# Altium schematic sheet NucleoShield.SchDoc(NucleoShield)
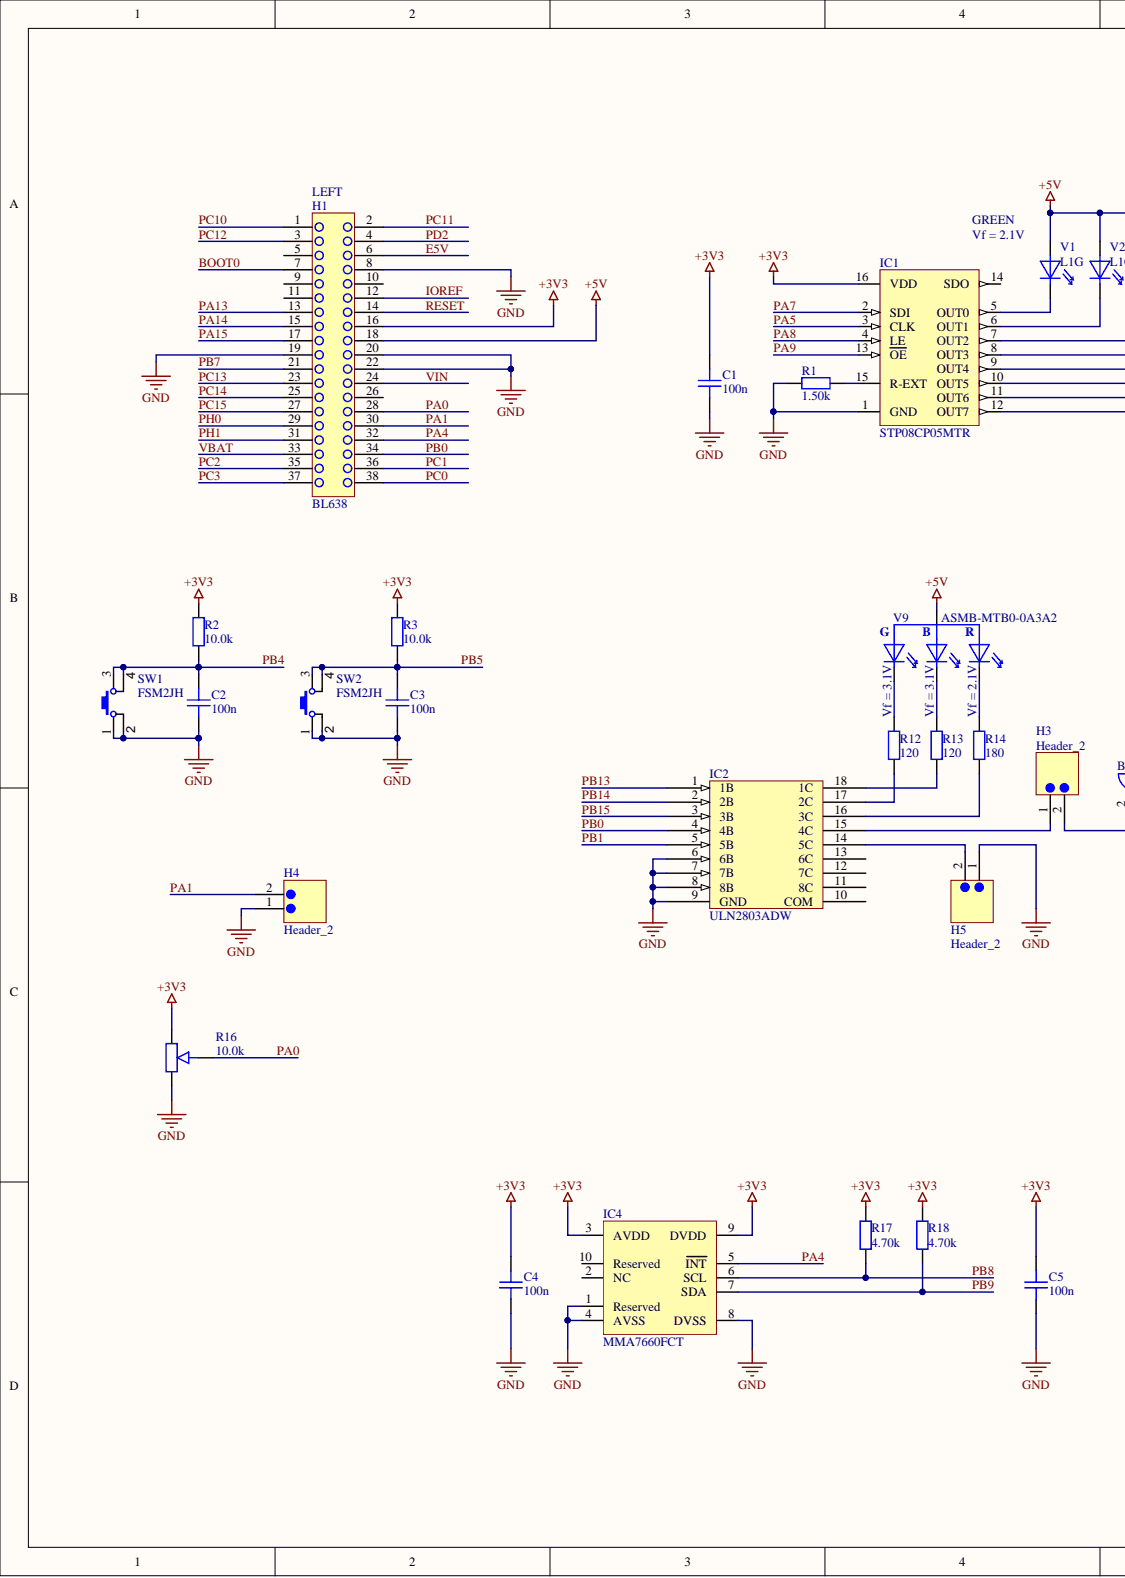
[diagram p0: NucleoShield.SchDoc, left]
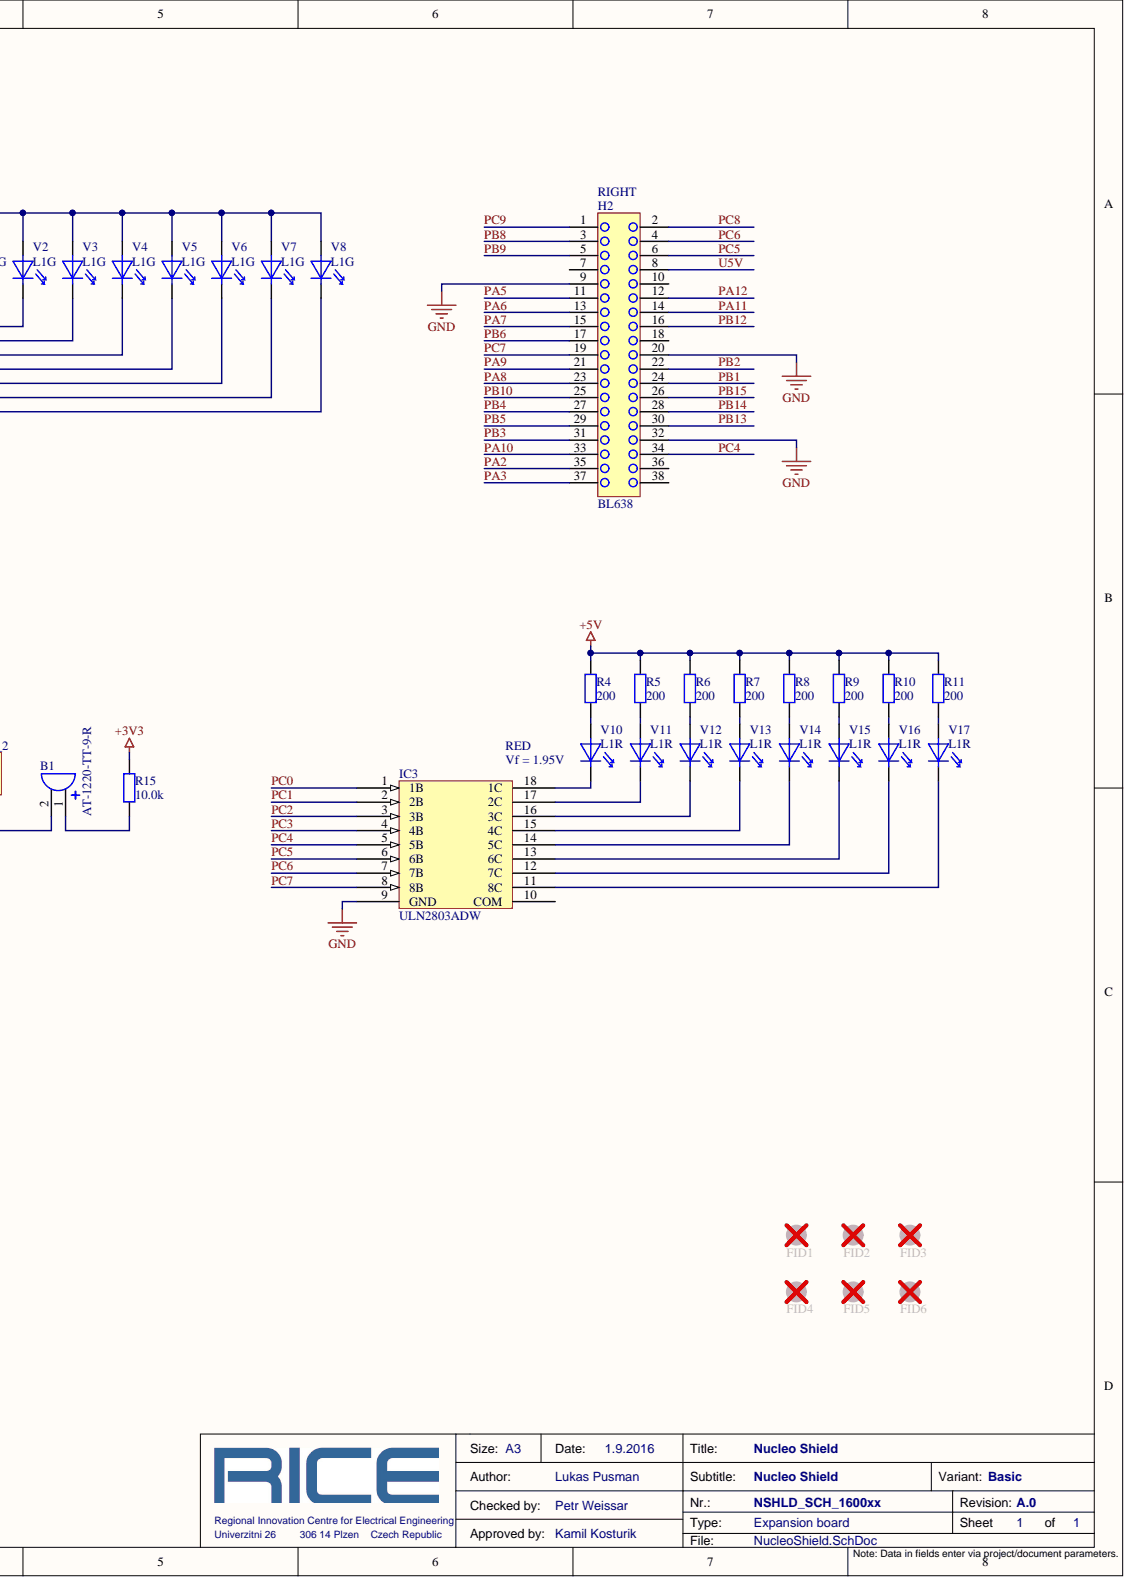
[diagram p1: NucleoShield.SchDoc, right]

## Parts
B1  AT-1220-TT-9-R  [th_buz_AT-1220-TT-9-R]
C1  100n  [sm_cap_0603]
C2  100n  [sm_cap_0603]
C3  100n  [sm_cap_0603]
C4  100n  [sm_cap_0603]
C5  100n  [sm_cap_0603]
FID1  Fiducial  [SM_mch_FIDUCIAL]  (value not drawn)
FID2  Fiducial  [SM_mch_FIDUCIAL]  (value not drawn)
FID3  Fiducial  [SM_mch_FIDUCIAL]  (value not drawn)
FID4  Fiducial  [SM_mch_FIDUCIAL]  (value not drawn)
FID5  Fiducial  [SM_mch_FIDUCIAL]  (value not drawn)
FID6  Fiducial  [SM_mch_FIDUCIAL]  (value not drawn)
H1  BL638  [th_con_rec2x19_pit100]
H2  BL638  [th_con_rec2x19_pit100]
H3  Header_2  [th_con_HDR1X2_pit100]
H4  Header_2  [th_con_HDR1X2_pit100]
H5  Header_2  [th_con_HDR1X2_pit100]
IC1  STP08CP05MTR  [SM_IO_SO16_W160]
IC2  ULN2803ADW  [SM_IO_SOIC18_PIT50]
IC3  ULN2803ADW  [SM_IO_SOIC18_PIT50]
IC4  MMA7660FCT  [SM_IO_VFDFN10]
R1  1.50k  [sm_res_0603]
R2  10.0k  [sm_res_0603]
R3  10.0k  [sm_res_0603]
R4  200  [sm_res_0603]
R5  200  [sm_res_0603]
R6  200  [sm_res_0603]
R7  200  [sm_res_0603]
R8  200  [sm_res_0603]
R9  200  [sm_res_0603]
R10  200  [sm_res_0603]
R11  200  [sm_res_0603]
R12  120  [sm_res_0603]
R13  120  [sm_res_0603]
R14  180  [sm_res_0603]
R15  10.0k  [sm_res_0603]
R16  10.0k  [TH_RES_TRIM_PT15]
R17  4.70k  [sm_res_0603]
R18  4.70k  [sm_res_0603]
SW1  FSM2JH  [th_swi_lph]
SW2  FSM2JH  [th_swi_lph]
V1  L1G  [sm_opt_led0805]
V2  L1G  [sm_opt_led0805]
V3  L1G  [sm_opt_led0805]
V4  L1G  [sm_opt_led0805]
V5  L1G  [sm_opt_led0805]
V6  L1G  [sm_opt_led0805]
V7  L1G  [sm_opt_led0805]
V8  L1G  [sm_opt_led0805]
V9  ASMB-MTB0-0A3A2  [sm_opt_LED_PLCC-4]
V10  L1R  [sm_opt_led0805]
V11  L1R  [sm_opt_led0805]
V12  L1R  [sm_opt_led0805]
V13  L1R  [sm_opt_led0805]
V14  L1R  [sm_opt_led0805]
V15  L1R  [sm_opt_led0805]
V16  L1R  [sm_opt_led0805]
V17  L1R  [sm_opt_led0805]

## Nets
+3V3: C1.2 C4.2 C5.2 H1.16 IC1.16(VDD) IC4.3(AVDD) IC4.9(DVDD) R2.2 R3.2 R15.2 R16.3 R17.2 R18.2
+5V: H1.18 R4.2 R5.2 R6.2 R7.2 R8.2 R9.2 R10.2 R11.2 V1.1 V2.1 V3.1 V4.1 V5.1 V6.1 V7.1 V8.1 V9.3
GND: C1.1 C2.1 C3.1 C4.1 C5.1 H1.8 H1.19 H1.20 H1.22 H2.9 H2.20 H2.32 H4.1 H5.1 IC1.1(GND) IC2.6(6B) IC2.7(7B) IC2.8(8B) IC2.9(GND) IC3.9(GND) IC4.1(Reserved) IC4.4(AVSS) IC4.8(DVSS) R1.1 R16.1 SW1.1 SW1.2 SW2.1 SW2.2
BOOT0: H1.7
E5V: H1.6
IOREF: H1.12
PA0: H1.28 R16.2
PA1: H1.30 H4.2
PA2: H2.35
PA3: H2.37
PA4: H1.32 IC4.5(INT)
PA5: H2.11 IC1.3(CLK)
PA6: H2.13
PA7: H2.15 IC1.2(SDI)
PA8: H2.23 IC1.4(LE)
PA9: H2.21 IC1.13(OE)
PA10: H2.33
PA11: H2.14
PA12: H2.12
PA13: H1.13
PA14: H1.15
PA15: H1.17
PB0: H1.34 IC2.4(4B)
PB1: H2.24 IC2.5(5B)
PB2: H2.22
PB3: H2.31
PB4: C2.2 H2.27 R2.1 SW1.3 SW1.4
PB5: C3.2 H2.29 R3.1 SW2.3 SW2.4
PB6: H2.17
PB7: H1.21
PB8: H2.3 IC4.6(SCL) R17.1
PB9: H2.5 IC4.7(SDA) R18.1
PB10: H2.25
PB12: H2.16
PB13: H2.30 IC2.1(1B)
PB14: H2.28 IC2.2(2B)
PB15: H2.26 IC2.3(3B)
PC0: H1.38 IC3.1(1B)
PC1: H1.36 IC3.2(2B)
PC2: H1.35 IC3.3(3B)
PC3: H1.37 IC3.4(4B)
PC4: H2.34 IC3.5(5B)
PC5: H2.6 IC3.6(6B)
PC6: H2.4 IC3.7(7B)
PC7: H2.19 IC3.8(8B)
PC8: H2.2
PC9: H2.1
PC10: H1.1
PC11: H1.2
PC12: H1.3
PC13: H1.23
PC14: H1.25
PC15: H1.27
PD2: H1.4
PH0: H1.29
PH1: H1.31
RESET: H1.14
U5V: H2.8
VBAT: H1.33
VIN: H1.24
NetB1_1: B1.1 R15.1
NetB1_2: B1.2 H3.2
NetH3_1: H3.1 IC2.15(4C)
NetH5_2: H5.2 IC2.14(5C)
NetIC1_5: IC1.5(OUT0) V1.2
NetIC1_6: IC1.6(OUT1) V2.2
NetIC1_7: IC1.7(OUT2) V3.2
NetIC1_8: IC1.8(OUT3) V4.2
NetIC1_9: IC1.9(OUT4) V5.2
NetIC1_10: IC1.10(OUT5) V6.2
NetIC1_11: IC1.11(OUT6) V7.2
NetIC1_12: IC1.12(OUT7) V8.2
NetIC1_15: IC1.15(R-EXT) R1.2
NetIC2_16: IC2.16(3C) R14.1
NetIC2_17: IC2.17(2C) R12.1
NetIC2_18: IC2.18(1C) R13.1
NetIC3_11: IC3.11(8C) V17.2
NetIC3_12: IC3.12(7C) V16.2
NetIC3_13: IC3.13(6C) V15.2
NetIC3_14: IC3.14(5C) V14.2
NetIC3_15: IC3.15(4C) V13.2
NetIC3_16: IC3.16(3C) V12.2
NetIC3_17: IC3.17(2C) V11.2
NetIC3_18: IC3.18(1C) V10.2
NetR4_1: R4.1 V10.1
NetR5_1: R5.1 V11.1
NetR6_1: R6.1 V12.1
NetR7_1: R7.1 V13.1
NetR8_1: R8.1 V14.1
NetR9_1: R9.1 V15.1
NetR10_1: R10.1 V16.1
NetR11_1: R11.1 V17.1
NetR12_2: R12.2 V9.1
NetR13_2: R13.2 V9.2
NetR14_2: R14.2 V9.4

Not connected: H1 5 9 10 11 26; H2 7 10 18 36 38; IC1 14(SDO); IC2 10(COM) 11(8C) 12(7C) 13(6C); IC3 10(COM); IC4 2(NC) 10(Reserved)

## Library data of the parts
B1 = MOD_000020: Magnetic Signal Buzzer, Single Tone 2.048kHz, 3.0-5.0Vp-p, 35mA, 85dB@3.5V/10cm; Manufacturer: PUI Audio, Inc.; ManufacturerID: PUIAudio; Part Category: Comunication/acustic; Part Select: TMOD0001000800000000; Supp Part number: 668-1468-ND; Active: 1; Cat1: Comunication; Cat2: acustic; Database Table Name: _modules; Designer: Lukas Pusman; Exact price 2: 0; ID: 4186; LasrRevisionNote: new release; Max Oper Temperature: 70; Min Oper Temperature: -20; PCB Status: electrical; Alternative: 1; Component Kind: Standard; ComponentLink1Description: Datasheet; ComponentLink1URL: \\afs\zcu.cz\project\departments\fel\rice\projects\General\Libraries\LIB_Components\PDF\Semiconductor\Modules\AT-1220-TT-9-R.pdf; ComponentLink2Description: Magnetic Buzzer; Exact price: 1; Exaxt price 3: 0; FIT: 1; LastRevisionDate: 11.5.2016; Library Name: Lib_Components_SQL.DbLib; ManufacturerURL: http://www.puiaudio.com/; Manuf Part Number: AT-1220-TT-9-R; Part Number: MOD_000020; PartNumber: MOD_000020; PartQuantity: 1; Part type: MOD; Preference: 1; Price: 0; Price 2: 0; Price 3: 0; Publishied: 11.5.2016; ROHS: 1; Simulation: nosim; Stock: 0; Supplier: Digikey; Supplier2: N/A; Supplier3: N/A; SupplierID: Digikey; SupplierID2: no supplier; SupplierID3: no supplier; SupplierURL: http://www.digikey.com/; SupplierURL2: N/A; SupplierURL3: N/A; Value: AT-1220-TT-9-R; Publisher: Jindřich Křivka
C1-C5 = CAP_000000: CAP CER .10UF 50V X7R 10% 0603; Manufacturer: TDK Corporation; ManufacturerID: TDK; Manuf Part Number: C1608X7R1H104K; Part Category: Ceramic/0603/X7R; Part Select: SCAP0001000300026100; Supp Part number: 445-1314-2-ND; Active: 1; Cat1: Ceramic; Cat2: 0603; Database Table Name: _capacitors; Designer: Tomáš Košan; FIT: 0,100000001490116; ID: 4; LasrRevisionNote: new release; Max Oper Temperature: 125; Min Oper Temperature: -55; PCB Status: Electrical; Preference: 100; Alternative: 1; Cat3: X7R; Component Kind: Standard; ComponentLink1Description: Datasheet; ComponentLink1URL: \\afs\zcu.cz\project\departments\fel\rice\projects\General\Libraries\LIB_Components\PDF\passive\capacitors\Cxxxx_TDK.pdf; Dielectric: X7R; Exact price: 1; LastRevisionDate: 11.5.2011; Library Name: Lib_Components_SQL.DbLib; ManufacturerURL: http://www.tdk.com/; Part Number: CAP_000000; PartNumber: CAP_000000; PartQuantity: 1; Part type: CAP; PCB3D: sm_cap_0603; Price: 0,112099997699261; Price 2: 0; Price 3: 0; Publishied: 11.5.2011; Quantity: 1; ROHS: 1; Simulation: cap; Stock: 4077; Supplier: Digikey; Supplier2: N/A; Supplier3: N/A; SupplierID: Digikey; SupplierID2: no supplier; SupplierID3: no supplier; SupplierURL: http://www.digikey.com/; SupplierURL2: N/A; SupplierURL3: N/A; Tolerance: 10%; Value: 100n; Voltage: 50V; Publisher: Libor Polacek
FID1-FID6 = MCH_000004: Fiducial global circle 1mm; ManufacturerID: no manufacturer; Manuf Part Number: x; Part Category: Virtual/Fiducial; Part Select: SMCH0002000200000000; Active: 1; Cat1: Virtual; Database Table Name: _Mechanicals; Designer: Libor Polacek; Exact price: 0; ID: 1091; LastRevisionNote: new release; Alternative: 1; Cat2: Fiducial; Component Kind: Standard; ComponentLink1Description: Datasheet; ComponentLink1URL: \\afs\zcu.cz\project\departments\fel\rice\projects\General\Libraries\LIB_Components\PDF\; ComponentLink2URL: \\afs\zcu.cz\project\departments\fel\rice\projects\General\Libraries\LIB_Components\PDF\; FIT: 0; Function Type: fiducial; LastRevisionDate: 8.9.2011; Library Name: Lib_Components_SQL.DbLib; Max Oper Temperature: 0; Min Oper Temperature: 0; Part Number: MCH_000004; PartNumber: MCH_000004; PartQuantity: 1; Part type: MCH; PCB3D: sm_vir_fiducial; PCB Status: Virtual; Preference: 0; Price: 0; Price 2: 0; Price 3: 0; Publishied: 8.9.2011; Quantity: 1; ROHS: 1; Simulation: nosim; Stock: 0; Supplier: N/A; Supplier2: N/A; Supplier3: N/A; SupplierID: no supplier; SupplierID2: no supplier; SupplierID3: no supplier; SupplierURL: N/A; SupplierURL2: N/A; SupplierURL3: N/A; Supp Part number: x; Value: Fiducial; Publisher: Libor Polacek
H1 H2 = CON_000292: CON Receptacle 2x19 100 mil; Manufacturer: Fischer Elektronik; ManufacturerID: Fischer; Part Category: Pin rows/Receptacle; Part Select: TCON0001000200002400; Active: 1; Cat1: Pin rows; Database Table Name: _connectors; Design: RECEPTACLE 100mil; Designer: Lukas Pusman; Exact price: 0; FIT: 10; ID: 4180; Max Oper Temperature: 125; Min Oper Temperature: -65; PCB Status: Electrical; Alternative: 1; Cat2: Receptacle; Component Kind: Standard; ComponentLink1Description: Datasheet; ComponentLink1URL: \\afs\zcu.cz\project\departments\fel\rice\projects\General\Libraries\LIB_Components\PDF\Passive\Connectors\f.con.e.pdf#page=63; Exact price 2: 0; Exaxt price 3: 0; LatestRevisionDate: 09-Sep-2011; LatestRevisionNote: Re-released for DXP Platform.; Library Name: Lib_Components_SQL.DbLib; ManufacturerURL: http://www.fischerelektronik.de/index.php/home_en/?&L=1; Manuf Part Number: BL638; Part Number: CON_000292; PartNumber: CON_000292; PartQuantity: 1; Part type: CON; Pin: 38; Preference: 0; Price: 10; Price 2: 0; Price 3: 0; Published: 15.6.2011; ROHS: 1; Signal Integrity: Connector; Simulation: nosim; Stock: 0; Supplier: Fischer Elektronik; Supplier2: N/A; Supplier3: N/A; SupplierID: Fischer; SupplierID2: no supplier; SupplierID3: no supplier; SupplierURL: http://www.fischerelektronik.de/index.php/home_en/?&L=1; SupplierURL2: N/A; SupplierURL3: N/A; Supp Part number: BL638; Value: BL638; Publisher: Altium Limited
H3-H5 = CON_000006: CON 2pin header 100mill 5,4mm; Manufacturer: Fischer Elektronik; ManufacturerID: Fischer; Manuf Part Number: SL1111202G; Part Category: Pin rows/Header; Part Select: TCON0001000100001200; Active: 1; Cat1: Pin rows; Database Table Name: _connectors; Designer: Libor Polacek; Eratasheet: \\afs\zcu.cz\project\departments\fel\rice\projects\General\Libraries\LIB_Components\PDF\; Exact price: 0; FIT: 2; ID: 901; LastRevisionNote: Initial version; Max Oper Temperature: 125; Min Oper Temperature: -65; PCB Status: Electrical; Alternative: 1; Cat2: Header; Component Kind: Standard; ComponentLink1Description: Datasheet; ComponentLink1URL: \\afs\zcu.cz\project\departments\fel\rice\projects\General\Libraries\LIB_Components\PDF\Passive\Connectors\f.con.e.pdf#page=40; Design: HEADER 100mill; LastRevisionDate: 15.6.2011; Library Name: Lib_Components_SQL.DbLib; ManufacturerURL: http://www.fischerelektronik.de/index.php/home_en/?&L=1; Part Number: CON_000006; PartNumber: CON_000006; PartQuantity: 1; Part type: CON; PCB3D: th_con_HDR1X2; Pin: 2; Preference: 0; Price: 0; Price 2: 0; Price 3: 0; Published: 15.6.2011; ROHS: 1; Signal Integrity: Connector; Simulation: nosim; Stock: 30; Supplier: Fischer Elektronik; Supplier2: N/A; Supplier3: N/A; SupplierID: Fischer; SupplierID2: no supplier; SupplierID3: no supplier; SupplierURL: http://www.fischerelektronik.de/index.php/home_en/?&L=1; SupplierURL2: N/A; SupplierURL3: N/A; Supp Part number: SL1111202G; Value: Header_2; Publisher: Turjanica
IC1 = ICS_000539: ICS 8-Bit Parallel-Out Serial Shift Register 3.0-5.5V 20ns SOIC16; Manufacturer: ST Microelectronics; ManufacturerID: STM; Part Category: Digital/Shift register; Part Select: SICS0002000800000000; Supp Part number: 497-6268-1-ND; Active: 1; Cat1: Digital; Database Table Name: _ics; Designer: Lukas Pusman; Exact price 2: 0; FIT: 8; ID: 4184; LastRevisionNote: new release; Max Oper Temperature: 125; Min Oper Temperature: -40; PCB Status: Electrical; Alternative: 1; Cat2: Shift register; Component Kind: Standard; ComponentLink1Description: Datasheet; ComponentLink1URL: \\afs\zcu.cz\project\departments\fel\rice\projects\General\Libraries\LIB_Components\PDF\Semiconductor\Logic\ShiftRegister\STP08CP05.pdf; ComponentLink2Description: Family; Exact price: 1; Exaxt price 3: 0; Function Type: Shift register; LastRevisionDate: 20.7.2016; Library Name: Lib_Components_SQL.DbLib; ManufacturerURL: http://www.st.com/internet/com/home/home.jsp; Manuf Part Number: STP08CP05MTR; Part Number: ICS_000539; PartNumber: ICS_000539; PartQuantity: 1; Part type: ICS; Preference: 0; Price: 21; Price 2: 0; Price 3: 0; Published: 20.7.2016; ROHS: 1; Simulation: nosim; Stock: 0; Supplier: Digikey; Supplier2: N/A; Supplier3: N/A; SupplierID: Digikey; SupplierID2: no supplier; SupplierID3: no supplier; SupplierURL: http://www.digikey.com/; SupplierURL2: N/A; SupplierURL3: N/A; Technology: CMOS; Value: STP08CP05MTR; Publisher: Lukas Pusman
IC2 IC3 = ICS_000102: ICS 8x NPN darlington, 50V, 0.5A, common clamping diode; Manufacturer: Texas Instruments; ManufacturerID: TI; Part Category: Digital/Level shifter; Part Select: SICS0002000600000000; Supp Part number: GES05014097; Supp Part number 2: 296-15777-1-ND; Active: 1; Cat1: Digital; Cat2: Level shifter; Database Table Name: _ics; Designer: Tomáš Košan; Exact price 2: 0; Function Type: Power level shifter; ID: 1394; LastRevisonNote: new release; Max Oper Temperature: 85; Min Oper Temperature: -40; PCB Status: Electrical; Alternative: 1; Component Kind: Standard; ComponentLink1Description: Datasheet; ComponentLink1URL: \\afs\zcu.cz\project\departments\fel\rice\projects\General\Libraries\LIB_Components\PDF\Semiconductor\Logic\LevelShifter\uln2803ad.pdf; Exact price: 1; Exaxt price 3: 0; FIT: 0; LastRevisionDate: 21.12.2011; Library Name: Lib_Components_SQL.DbLib; ManufacturerURL: http://www.ti.com/; Manuf Part Number: ULN2803ADW; Marking: ULN2803A; Part Number: ICS_000102; PartNumber: ICS_000102; PartQuantity: 1; Part type: ICS; Preference: 1; Price: 18,8999996185303; Price 2: 18; Price 3: 0; Published: 21.12.2011; ROHS: 1; Simulation: nosim; Stock: 0; Supplier: GES; Supplier2: Digikey; Supplier3: N/A; SupplierID: GES; SupplierID2: Digikey; SupplierID3: no supplier; SupplierURL: http://www.ges.cz/cz/; SupplierURL2: http://www.digikey.com/; SupplierURL3: N/A; Technology: TTL; Value: ULN2803ADW; Publisher: Tomas Kosan
IC4 = ICS_000538: 3-Axis Digital Accelerometer, 6-Bit, I2C, +-1.5g; Manufacturer: Freescale; Part Category: Digital/sensor/Acceleration; Part Select: SICS0002001600030000; Supp Part number: MMA7660FCT-ND; Active: 1; Cat2: sensor; Cat3: Acceleration; Database Table Name: _ics; Designer: Lukas Pusman; Exaxt price 3: 0; Function Type: 3-Axis Accelerometer; ID: 4182; LastRevisionNote: new release; Max Oper Temperature: 85; Min Oper Temperature: -40; PCB Status: Electrical; Alternative: 1; Cat1: Digital; Component Kind: Standard; ComponentLink1Description: Datasheet; ComponentLink1URL: \\afs\zcu.cz\project\departments\fel\rice\projects\General\Libraries\LIB_Components\PDF\Semiconductor\Logic\Converter\Acceleration\MMA7660FCT.pdf; Exact price: 1; Exact price 2: 1; FIT: 1; LastRevisionDate: 26.5.2014; Library Name: Lib_Components_SQL.DbLib; ManufacturerID: Freescale; ManufacturerURL: http://www.freescale.com/; Manuf Part Number: MMA7660FCT; Part Number: ICS_000538; PartNumber: ICS_000538; PartQuantity: 1; Part type: ICS; Preference: 0; Price: 38; Price 2: 0; Price 3: 0; Published: 26.5.2014; ROHS: 1; Simulation: nosim; Stock: 0; Supplier: Digikey; Supplier2: N/A; Supplier3: N/A; SupplierID: Digikey; SupplierID2: no supplier; SupplierID3: no supplier; SupplierURL: http://www.digikey.com/; SupplierURL2: N/A; SupplierURL3: N/A; Technology: MEMS; Value: MMA7660FCT; Publisher: Michael Holik
R1 = RES_000077: RES 0603 1.50k 1% 100ppm SMD thick film; Manufacturer: Panasonic; Manuf Part Number: ERJ3EKF1501V; Part Category: Thick film/0603; Part Select: SRES0001000300004150; Supp Part number: P1.50kHTR-ND; Active: 1; Cat2: 0603; Database Table Name: _Resistors; Designer: LiPo; FIT: 0,100000001490116; ID: 438; LastRevisionNote: new release; Max Oper Temperature: 125; Min Oper Temperature: -55; PCB Status: Electrical; Power: 63mW; Alternative: 1; Cat1: Thick film; Component Kind: Standard; ComponentLink1Description: Datasheet; ComponentLink1URL: \\afs\zcu.cz\project\departments\fel\rice\projects\General\Libraries\LIB_Components\PDF\passive\resistors\ERJ_EKF_Panasonic.pdf; Exact price: 1; LastRevisionDate: 11.5.2011; Library Name: Lib_Components_SQL.DbLib; ManufacturerID: Panasonic; ManufacturerURL: http://www.industrial.panasonic.com/; Part Number: RES_000077; PartNumber: RES_000077; PartQuantity: 1; Part type: RES; PCB3D: sm_res_0603; Price: 0,0044999998062849; Price 2: 0; Price 3: 0; Published: 11.5.2011; Quantity: 1; ROHS: 1; Simulation: res; Stock: 3623; Supplier: Digikey; Supplier2: N/A; Supplier3: N/A; SupplierID: Digikey; SupplierID2: no supplier; SupplierID3: no supplier; SupplierURL: http://www.digikey.com/; SupplierURL2: N/A; SupplierURL3: N/A; Thermal Stability: 100ppm; Tolerance: 1%; Value: 1.50k; Publisher: Libor Polacek
R2 R3 R15 = RES_000097: RES 0603 10.0k 1% 100ppm SMD thick film; Manufacturer: Panasonic; Manuf Part Number: ERJ3EKF1002V; Part Category: Thick film/0603; Part Select: SRES0001000300005100; Supp Part number: P10.0kHTR-ND; Active: 1; Cat2: 0603; Database Table Name: _resistors; Designer: LiPo; FIT: 0,100000001490116; ID: 458; LastRevisionNote: new release; Max Oper Temperature: 125; Min Oper Temperature: -55; PCB Status: Electrical; Power: 63mW; Alternative: 1; Cat1: Thick film; Component Kind: Standard; ComponentLink1Description: Datasheet; ComponentLink1URL: \\afs\zcu.cz\project\departments\fel\rice\projects\General\Libraries\LIB_Components\PDF\passive\resistors\ERJ_EKF_Panasonic.pdf; Exact price: 1; LastRevisionDate: 11.5.2011; Library Name: Lib_Components_SQL.DbLib; ManufacturerID: Panasonic; ManufacturerURL: http://www.industrial.panasonic.com/; Part Number: RES_000097; PartNumber: RES_000097; PartQuantity: 1; Part type: RES; PCB3D: sm_res_0603; Price: 0,0044999998062849; Price 2: 0; Price 3: 0; Published: 11.5.2011; Quantity: 1; ROHS: 1; Simulation: res; Stock: 1663; Supplier: Digikey; Supplier2: N/A; Supplier3: N/A; SupplierID: Digikey; SupplierID2: no supplier; SupplierID3: no supplier; SupplierURL: http://www.digikey.com/; SupplierURL2: N/A; SupplierURL3: N/A; Thermal Stability: 100ppm; Tolerance: 1%; Value: 10.0k; Publisher: Libor Polacek
R4-R11 = RES_000056: RES 0603 200R 1% 100ppm SMD thick film; Manufacturer: Panasonic; Manuf Part Number: ERJ3EKF2000V; Part Category: Thick film/0603; Part Select: SRES0001000300003200; Supp Part number: P200HTR-ND; Active: 1; Cat2: 0603; Database Table Name: _Resistors; Designer: LiPo; FIT: 0,100000001490116; ID: 417; LastRevisionNote: new release; Max Oper Temperature: 125; Min Oper Temperature: -55; PCB Status: Electrical; Power: 63mW; Alternative: 1; Cat1: Thick film; Component Kind: Standard; ComponentLink1Description: Datasheet; ComponentLink1URL: \\afs\zcu.cz\project\departments\fel\rice\projects\General\Libraries\LIB_Components\PDF\passive\resistors\ERJ_EKF_Panasonic.pdf; Exact price: 1; LastRevisionDate: 11.5.2011; Library Name: Lib_Components_SQL.DbLib; ManufacturerID: Panasonic; ManufacturerURL: http://www.industrial.panasonic.com/; Part Number: RES_000056; PartNumber: RES_000056; PartQuantity: 1; Part type: RES; PCB3D: sm_res_0603; Price: 0,0044999998062849; Price 2: 0; Price 3: 0; Published: 11.5.2011; Quantity: 1; ROHS: 1; Simulation: res; Stock: 4885; Supplier: Digikey; Supplier2: N/A; Supplier3: N/A; SupplierID: Digikey; SupplierID2: no supplier; SupplierID3: no supplier; SupplierURL: http://www.digikey.com/; SupplierURL2: N/A; SupplierURL3: N/A; Thermal Stability: 100ppm; Tolerance: 1%; Value: 200; Publisher: Libor Polacek
R12 R13 = RES_000051: RES 0603 120R 1% 100ppm SMD thick film; Manufacturer: Panasonic; Manuf Part Number: ERJ3EKF1200V; Part Category: Thick film/0603; Part Select: SRES0001000300003120; Supp Part number: P120HTR-ND; Active: 1; Cat2: 0603; Database Table Name: _resistors; Designer: LiPo; FIT: 0,100000001490116; ID: 412; LastRevisionNote: new release; Max Oper Temperature: 125; Min Oper Temperature: -55; PCB Status: Electrical; Power: 63mW; Alternative: 1; Cat1: Thick film; Component Kind: Standard; ComponentLink1Description: Datasheet; ComponentLink1URL: \\afs\zcu.cz\project\departments\fel\rice\projects\General\Libraries\LIB_Components\PDF\passive\resistors\ERJ_EKF_Panasonic.pdf; Exact price: 1; LastRevisionDate: 11.5.2011; Library Name: Lib_Components_SQL.DbLib; ManufacturerID: Panasonic; ManufacturerURL: http://www.industrial.panasonic.com/; Part Number: RES_000051; PartNumber: RES_000051; PartQuantity: 1; Part type: RES; PCB3D: sm_res_0603; Price: 0,0044999998062849; Price 2: 0; Price 3: 0; Published: 11.5.2011; Quantity: 1; ROHS: 1; Simulation: res; Stock: 4540; Supplier: Digikey; Supplier2: N/A; Supplier3: N/A; SupplierID: Digikey; SupplierID2: no supplier; SupplierID3: no supplier; SupplierURL: http://www.digikey.com/; SupplierURL2: N/A; SupplierURL3: N/A; Thermal Stability: 100ppm; Tolerance: 1%; Value: 120; Publisher: Libor Polacek
R14 = RES_000055: RES 0603 180R 1% 100ppm SMD thick film; Manufacturer: Panasonic; Manuf Part Number: ERJ3EKF1800V; Part Category: Thick film/0603; Part Select: SRES0001000300003180; Supp Part number: P180HTR-ND; Active: 1; Cat2: 0603; Database Table Name: _resistors; Designer: LiPo; FIT: 0,100000001490116; ID: 416; LastRevisionNote: new release; Max Oper Temperature: 125; Min Oper Temperature: -55; PCB Status: Electrical; Power: 63mW; Alternative: 1; Cat1: Thick film; Component Kind: Standard; ComponentLink1Description: Datasheet; ComponentLink1URL: \\afs\zcu.cz\project\departments\fel\rice\projects\General\Libraries\LIB_Components\PDF\passive\resistors\ERJ_EKF_Panasonic.pdf; Exact price: 1; LastRevisionDate: 11.5.2011; Library Name: Lib_Components_SQL.DbLib; ManufacturerID: Panasonic; ManufacturerURL: http://www.industrial.panasonic.com/; Part Number: RES_000055; PartNumber: RES_000055; PartQuantity: 1; Part type: RES; PCB3D: sm_res_0603; Price: 0,0044999998062849; Price 2: 0; Price 3: 0; Published: 11.5.2011; Quantity: 1; ROHS: 1; Simulation: res; Stock: 3496; Supplier: Digikey; Supplier2: N/A; Supplier3: N/A; SupplierID: Digikey; SupplierID2: no supplier; SupplierID3: no supplier; SupplierURL: http://www.digikey.com/; SupplierURL2: N/A; SupplierURL3: N/A; Thermal Stability: 100ppm; Tolerance: 1%; Value: 180; Publisher: Libor Polacek
R16 = RES_000622: RES VAR 10k, 20%; Manufacturer: Piher Sensors & Controls SA; ManufacturerID: Piher; Manuf Part Number: PT15NV02103A2020; Part Category: Variable/Trimmer; Part Select: TRES0008000100005100; Supp Part number: PT15NV-10K; Active: 1; Cat1: Variable; Cat2: Trimmer; Database Table Name: _resistors; Designer: Lukas Pusman; Exaxt price 3: 0; ID: 4218; LastRevisionNote: new release; Max Oper Temperature: 70; Min Oper Temperature: -25; PCB Status: Electrical; Power: 250mW; Alternative: 1; Component Kind: Standard; ComponentLink1Description: Datasheet; ComponentLink1URL: \\afs\zcu.cz\project\departments\fel\rice\projects\General\Libraries\LIB_Components\PDF\Passive\Resistors\Trimmer_Piher_PT15Nx.pdf; Exact price: 1; Exact price 2: 1; FIT: 0; LastRevisionDate: 11.5.2011; Library Name: Lib_Components_SQL.DbLib; ManufacturerURL: http://www.piher.net/sensors/; Part Number: RES_000622; PartNumber: RES_000622; PartQuantity: 1; Part type: RES; Preference: 0; Price: 11; Price 2: 0; Price 3: 0; Published: 11.5.2011; ROHS: 1; Simulation: nosim; Stock: 0; Supplier: TME; Supplier2: N/A; Supplier3: N/A; SupplierID: TME; SupplierID2: no supplier; SupplierID3: no supplier; SupplierURL: http://www.tme.eu/cz/; SupplierURL2: N/A; SupplierURL3: N/A; Tolerance: 20%; Value: 10.0k; Publisher: Libor Polacek
R17 R18 = RES_000089: RES 0603 4.70k 1% 100ppm SMD thick film; Manufacturer: Panasonic; Manuf Part Number: ERJ3EKF4701V; Part Category: Thick film/0603; Part Select: SRES0001000300004470; Supp Part number: P4.70kHTR-ND; Active: 1; Cat2: 0603; Database Table Name: _resistors; Designer: LiPo; FIT: 0,100000001490116; ID: 450; LastRevisionNote: new release; Max Oper Temperature: 125; Min Oper Temperature: -55; PCB Status: Electrical; Power: 63mW; Alternative: 1; Cat1: Thick film; Component Kind: Standard; ComponentLink1Description: Datasheet; ComponentLink1URL: \\afs\zcu.cz\project\departments\fel\rice\projects\General\Libraries\LIB_Components\PDF\passive\resistors\ERJ_EKF_Panasonic.pdf; Exact price: 1; LastRevisionDate: 11.5.2011; Library Name: Lib_Components_SQL.DbLib; ManufacturerID: Panasonic; ManufacturerURL: http://www.industrial.panasonic.com/; Part Number: RES_000089; PartNumber: RES_000089; PartQuantity: 1; Part type: RES; PCB3D: sm_res_0603; Price: 0,0044999998062849; Price 2: 0; Price 3: 0; Published: 11.5.2011; Quantity: 1; ROHS: 1; Simulation: res; Stock: 992; Supplier: Digikey; Supplier2: N/A; Supplier3: N/A; SupplierID: Digikey; SupplierID2: no supplier; SupplierID3: no supplier; SupplierURL: http://www.digikey.com/; SupplierURL2: N/A; SupplierURL3: N/A; Thermal Stability: 100ppm; Tolerance: 1%; Value: 4.70k; Publisher: Libor Polacek
SW1 SW2 = SWI_000006: MCH button switch; Manufacturer: TE connectivity; Part Category: Button/Closing; Part Select: TSWI0002000100000000; Supp Part number: 1555981; Active: 1; Cat2: Closing; Database Table Name: _switches; Designer: Jiri Cengery; Exact price 2: 0; FIT: 5; ID: 2202; Max Oper Temperature: 85; Min Oper Temperature: -40; PCB Status: Electrical; Alternative: 1; Cat1: Button; Component Kind: Standard; ComponentLink1Description: Datasheet; ComponentLink1URL: \\afs\zcu.cz\project\departments\fel\rice\projects\General\Libraries\LIB_Components\PDF\Passive\Switches\typ_LPV.pdf; ComponentLink2URL: \\afs\zcu.cz\project\departments\fel\rice\projects\General\Libraries\LIB_Components\PDF\Passive\Switches\TE_SWITCHES_CORE_PROGRAM_CATALOG_0308.pdf; Design: button; Exact price: 1; Exaxt price 3: 0; LatestRevisionDate: 17-Jul-2002; LatestRevisionNote: Re-released for DXP Platform.; Library Name: Lib_Components_SQL.DbLib; ManufacturerID: TE connectivity; ManufacturerURL: http://www.te.com/en/home.html; Manuf Part Number: FSM2JH; Part Number: SWI_000006; PartNumber: SWI_000006; PartQuantity: 1; Part type: SWI; Preference: 0; Price: 2; Price 2: 0; Price 3: 0; Published: 24-Mar-1999; ROHS: 1; Simulation: nosim; Stock: 0; Supplier: Farnell; Supplier2: N/A; Supplier3: N/A; SupplierID: Farnell; SupplierID2: no supplier; SupplierID3: no supplier; SupplierURL: http://cz.farnell.com/; SupplierURL2: N/A; SupplierURL3: N/A; Value: FSM2JH; Publisher: Altium Limited
V1-V8 = OPT_000057: OPT LED green 570nm 20mA 2,1V 0805; Manufacturer: kingbright; Manuf Part Number: APT2012CGCK; Part Category: LED/0805; Part Select: SOPT0001000200000000; Supp Part number: 754-1127-1-ND; Active: 1; Cat2: 0805; Database Table Name: _Optoelectronics; Designer: Lukas Pusman; Exact price 2: 0; FIT: 2; ID: 4468; LastRevisionNote: new release; Max Oper Temperature: 85; Min Oper Temperature: -40; PCB Status: Electrical; Alternative: 1; Cat1: LED; Color: green; Component Kind: Standard; ComponentLink1Description: Datasheet; ComponentLink1URL: \\afs\zcu.cz\project\departments\fel\rice\projects\General\Libraries\LIB_Components\PDF\Semiconductor\Analog\LED\APT2012CGCK.pdf; Exact price: 1; Exaxt price 3: 0; LastRevisionDate: 11.5.2011; Library Name: Lib_Components_SQL.DbLib; ManufacturerID: Kingbright; ManufacturerURL: www.kingbright.com; Part Number: OPT_000057; PartNumber: OPT_000057; PartQuantity: 1; Part type: OPT; Preference: 0; Price: 8; Price 2: 0; Price 3: 0; Published: 11.5.2011; Simulation: nosim; Stock: 0; Supplier: Digikey; Supplier2: N/A; Supplier3: N/A; SupplierID: Digikey; SupplierID2: no supplier; SupplierID3: no supplier; SupplierURL: http://www.digikey.com/; SupplierURL2: N/A; SupplierURL3: N/A; Value: L1G; Publisher: Libor Polacek
V9 = OPT_000055: OPT LED RGB 20mA PLCC-4; Manufacturer: Avago; Part Category: LED/PLCC-4; Part Select: SOPT0001000900000000; Supp Part number: 516-3279-1-ND; Active: 1; Database Table Name: _optoelectronics; Designer: Lukas Pusman; Exact price 2: 0; FIT: 3; ID: 4188; LastRevisionNote: new release; Max Oper Temperature: 100; Min Oper Temperature: -40; PCB Status: Electrical; Alternative: 1; Cat1: LED; Cat2: PLCC-4; Color: RGB; Component Kind: Standard; ComponentLink1Description: Datasheet; ComponentLink1URL: \\afs\zcu.cz\project\departments\fel\rice\projects\General\Libraries\LIB_Components\PDF\Semiconductor\Analog\LED\LED-Selection-Guide-2015_AV00-0244EN_073015-web.pdf; Exact price: 1; Exaxt price 3: 0; LastRevisionDate: 28.5.2015; Library Name: Lib_Components_SQL.DbLib; ManufacturerID: Avago; ManufacturerURL: www.avagotech.com; Manuf Part Number: ASMB-MTB0-0A3A2; Part Number: OPT_000055; PartNumber: OPT_000055; PartQuantity: 1; Part type: OPT; Preference: 0; Price: 16; Price 2: 0; Price 3: 0; Published: 28.5.2015; ROHS: 1; Simulation: nosim; Stock: 0; Supplier: Digikey; Supplier2: N/A; Supplier3: N/A; SupplierID: Digikey; SupplierID2: no supplier; SupplierID3: no supplier; SupplierURL: http://www.digikey.com/; SupplierURL2: N/A; SupplierURL3: N/A; Value: ASMB-MTB0-0A3A2; Publisher: Jindrich Krivka
V10-V17 = OPT_000056: OPT LED red 630nm 20mA 1,95V 0805; Manufacturer: kingbright; Manuf Part Number: APT2012SURCK; Part Category: LED/0805; Part Select: SOPT0001000200000000; Supp Part number: 754-1133-1-ND; Active: 1; Cat2: 0805; Database Table Name: _Optoelectronics; Designer: Lukas Pusman; Exact price 2: 0; FIT: 2; ID: 4466; LastRevisionNote: new release; Max Oper Temperature: 85; Min Oper Temperature: -40; PCB Status: Electrical; Alternative: 1; Cat1: LED; Color: red; Component Kind: Standard; ComponentLink1Description: Datasheet; ComponentLink1URL: \\afs\zcu.cz\project\departments\fel\rice\projects\General\Libraries\LIB_Components\PDF\Semiconductor\Analog\LED\APT2012SURCK.pdf; Exact price: 1; Exaxt price 3: 0; LastRevisionDate: 11.5.2011; Library Name: Lib_Components_SQL.DbLib; ManufacturerID: Kingbright; ManufacturerURL: www.kingbright.com; Part Number: OPT_000056; PartNumber: OPT_000056; PartQuantity: 1; Part type: OPT; Preference: 0; Price: 8; Price 2: 0; Price 3: 0; Published: 11.5.2011; Simulation: nosim; Stock: 0; Supplier: Digikey; Supplier2: N/A; Supplier3: N/A; SupplierID: Digikey; SupplierID2: no supplier; SupplierID3: no supplier; SupplierURL: http://www.digikey.com/; SupplierURL2: N/A; SupplierURL3: N/A; Value: L1R; Publisher: Libor Polacek
